# Altium schematic sheet InterlockControl.SchDoc
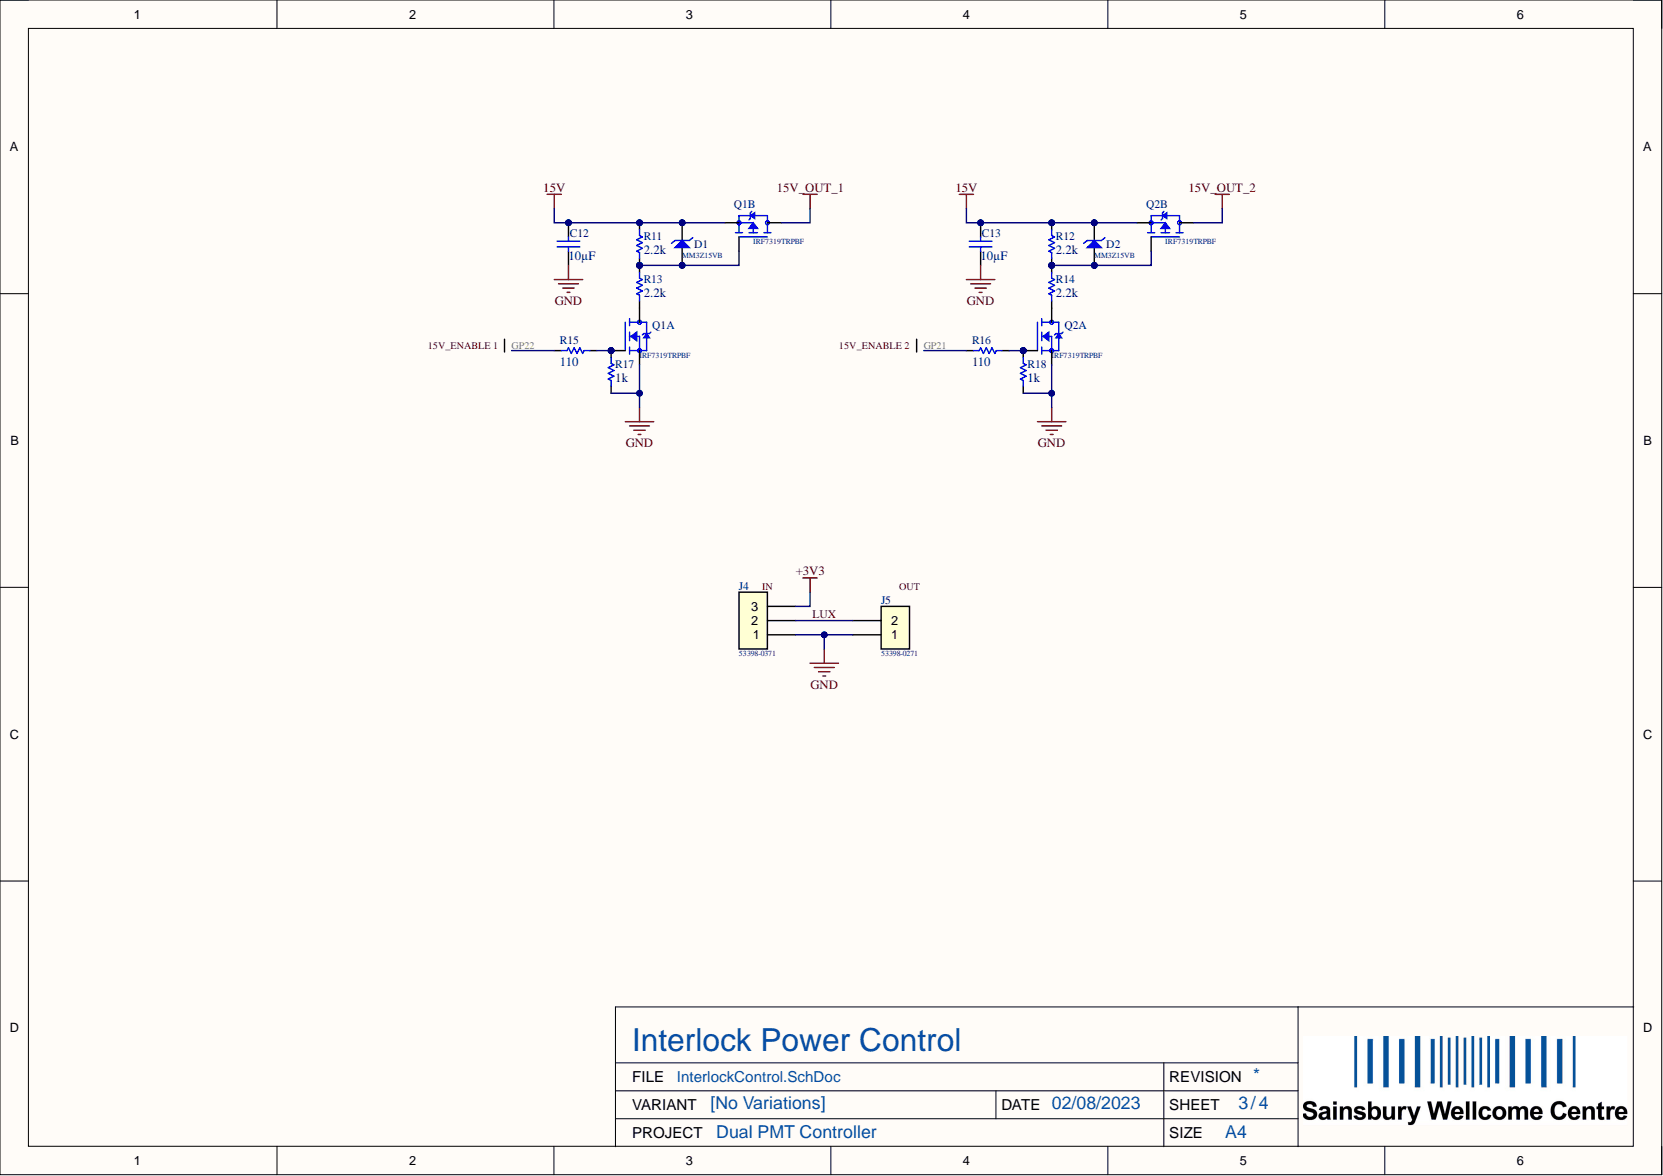
[diagram p0: InterlockControl.SchDoc]

## Parts
C12  value 10µF  [CAPC3225X279X50NL20T25]  (hidden comment: C1210-10uF-50V-10%-X7R)
C13  value 10µF  [CAPC3225X279X50NL20T25]  (hidden comment: C1210-10uF-50V-10%-X7R)
D1  MM3Z15VB  [SODFL250X100-2N]
D2  MM3Z15VB  [SODFL250X100-2N]
J4  53398-0371  [MOLX-53398-0371_V]
J5  53398-0271  [MOLX-53398-0271_V]
Q1  IRF7319TRPBF  [SOIC127P600X175-8N]  (parts A,B)
Q2  IRF7319TRPBF  [SOIC127P600X175-8N]  (parts A,B)
R11  value 2.2k  Resistance: 2.2k  [FP-CPF0603-IPC_B]  (hidden comment: R0603-2k2-1/16W-0.1%)
R12  value 2.2k  Resistance: 2.2k  [FP-CPF0603-IPC_B]  (hidden comment: R0603-2k2-1/16W-0.1%)
R13  value 2.2k  Resistance: 2.2k  [FP-CPF0603-IPC_B]  (hidden comment: R0603-2k2-1/16W-0.1%)
R14  value 2.2k  Resistance: 2.2k  [FP-CPF0603-IPC_B]  (hidden comment: R0603-2k2-1/16W-0.1%)
R15  value 110  [FP-CPF0603-IPC_B]  (hidden comment: R0603-110R-1/16W-0.1%)
R16  value 110  [FP-CPF0603-IPC_B]  (hidden comment: R0603-110R-1/16W-0.1%)
R17  value 1k  Resistance: 1k  [FP-CPF0603-IPC_B]  (hidden comment: R0603-1k-1/16W-0.1%)
R18  value 1k  Resistance: 1k  [FP-CPF0603-IPC_B]  (hidden comment: R0603-1k-1/16W-0.1%)

## Nets
15V: C12.2 C13.2 D1.2 D2.2 Q1.3 Q2.3 R11.2 R12.2
15V_OUT_1: Q1.5
15V_OUT_2: Q2.5
+3V3: J4.3
GND: C12.1 C13.1 J4.1 J5.1 Q1.1 Q2.1 R17.1 R18.1
GP21: R16.1
GP22: R15.1
LUX: J4.2 J5.2
NetD1_1: D1.1 Q1.4 R11.1 R13.2
NetD2_1: D2.1 Q2.4 R12.1 R14.2
NetQ1_2: Q1.2 R15.2 R17.2
NetQ1_7: Q1.7 R13.1
NetQ2_2: Q2.2 R16.2 R18.2
NetQ2_7: Q2.7 R14.1

## Library data of the parts
C12 C13 = CMP-611-0024-5: 10uF ±10% 50V Ceramic Capacitor X7R 1210 (3225 Metric); Pick&PlaceFootprint: C1210; Capacitance: 10uF; Capacitor Type: Ceramic; Case/Package: 1210; Component Kind: Standard; Dielectric: X7R; Mount: Surface Mount; RoHS: Yes; Tolerance: 10%; Voltage Rating: 50V; Library Name: Sainsbury Wellcome Centre
D1 D2 = CMP-822-0003-4: Zener Diode, 15V V(Z), 2%, 0.2W, Silicon, Unidirectional; PartNumber: MM3Z5V1B; Pick&PlaceFootprint: SOD323; Component Kind: Standard; Mount: Surface Mount; Library Name: Sainsbury Wellcome Centre
J4 = CMP-370-0033-4: Board Connector, 3 Contact(s), 1 Row(s), Male, Straight, 0.049 inch Pitch, Surface Mount Terminal, Locking, Natural Insulator, Receptacle; Board Mounting Option: SOLDER HOLDING POSTS; Body Breadth: 0.146inch; Body Depth: 0.185inch; Body Length: 0.335inch; Contact Pattern: RECTANGULAR; Contact Resistance: 20mR; Contact Style: Blade Pin; Dielectric Withstanding Voltage (AC): 250V; DIN Conformance: No; Durability: 30Cycles; Insulation Resistance: 100MR; Mating Information: MULTIPLE MATING PARTS AVAILABLE; Number Of Connectors: 1; Number of Contacts: 3; Option: General Purpose; Plating Thickness: 39uin; Polarization Key: POLARIZED HOUSING; Rated Current (Signal): 1A; Reference Standard: UL; Reliability: COMMERCIAL; Body/Shell Style: RECEPTACLE; Component Kind: Standard; Connector Type: BOARD CONNECTOR; Contact Finish - Mating: TIN (39) OVER NICKEL; Contact Finish - Termination: Tin (Sn); Contact Gender: Male; Contact Material: Bronze; Filter Feature: No; Flammability Rating: UL94 V-0; IEC Conformance: No; Insulation Color: Natural; Insulation Material: Nylon; JESD-609 Code: e3; Mating Contact Pitch: 0.049inch; Max Operating Temperature: 85°C; MIL Conformance: No; Min Operating Temperature: -40°C; Mixed Contacts: No; Mount: Board; Mounting Option 1: LOCKING; Mounting Style: STRAIGHT; Number Of PCB Rows: 1; Number of Rows Loaded: 1; PartNumber: 53398-0371; PCB Contact Pattern: RECTANGULAR; REACH SVHC: Yes; RoHS Compliant: Yes; Terminal Pitch: 1.25mm; Termination: SMD/SMT; Library Name: Sainsbury Wellcome Centre
J5 = CMP-370-0034-4: Board Connector, 2 Contact(s), 1 Row(s), Male, Straight, 0.049 inch Pitch, Surface Mount Terminal, Locking, Natural Insulator, Receptacle; Board Mounting Option: FITTING NAIL; Body Breadth: 0.165inch; Body Depth: 0.185inch; Body Length: 0.285inch; Contact Pattern: RECTANGULAR; Contact Resistance: 20mR; Contact Style: Blade Pin; Dielectric Withstanding Voltage (AC): 250V; DIN Conformance: No; Durability: 30Cycles; Insulation Resistance: 100MR; Mating Information: MULTIPLE MATING PARTS AVAILABLE; Number Of Connectors: 1; Number of Contacts: 2; Option: General Purpose; Plating Thickness: 39uin; Polarization Key: POLARIZED HOUSING; Rated Current (Signal): 1A; Reference Standard: UL; Reliability: COMMERCIAL; Body/Shell Style: RECEPTACLE; Component Kind: Standard; Connector Type: BOARD CONNECTOR; Contact Finish - Mating: MATTE TIN (39) OVER NICKEL; Contact Finish - Termination: Tin (Sn); Contact Gender: Male; Contact Material: Bronze; Filter Feature: No; Flammability Rating: UL94 V-0; IEC Conformance: No; Insulation Color: Natural; Insulation Material: Polyamide; JESD-609 Code: e3; Mating Contact Pitch: 0.049inch; Max Operating Temperature: 85°C; MIL Conformance: No; Min Operating Temperature: -40°C; Mixed Contacts: No; Mount: Board; Mounting Option 1: LOCKING; Mounting Style: STRAIGHT; Number Of PCB Rows: 1; Number of Rows Loaded: 1; PartNumber: 53398-0271; PCB Contact Pattern: RECTANGULAR; REACH SVHC: Yes; RoHS Compliant: Yes; Terminal Pitch: 1.25mm; Termination: SMD/SMT; Library Name: Sainsbury Wellcome Centre
Q1 Q2 = CMP-830-0006-2: Power Field-Effect Transistor, 6.5A I(D), 30V, 0.029ohm, 2-Element, N-Channel and P-Channel, Silicon, Metal-oxide Semiconductor FET, MS-012AA; Pick&PlaceFootprint: SOIC-8; Component Kind: Standard; Mount: Surface Mount; PartNumber: IRF7319TRPBF; Library Name: Sainsbury Wellcome Centre
R11-R14 = CMP-633-0070-10: Surface Mount Resistor 2.2k Ohm 1/16 W 0.1% 0603 (1608 Metric); Max Power Dissipation: 62.5 mW; Number of Resistors: 1; Pick&PlaceFootprint: R0603; Power Rating: 62.5mW; Temperature Coefficient: 25ppm/°C; Voltage Rating: 50V; Case Code (Imperial): 0603; Case Code (Metric): 1608; Case/Package: 0603; Component Kind: Standard; Composition: Thin Film; ELV: Compliant; Height: 0.45mm; Length: 1.6mm; Max Operating Temperature: 155°C; Min Operating Temperature: -55°C; Mount: Surface Mount; Number of Pins: 2; Number of Terminations: 2; Packaging: Tape and Reel; REACH SVHC: No SVHC; Resistance Tolerance: 0.1%; Resistor Type: Thin Film; RoHS: Yes; RoHS Compliant: Yes; Series: CPF; Size Code: 0603; Technology: Thin Film; Termination: Solder; Tolerance: 0.1%; Voltage Rating (DC): 50V; Weight: 7.1E-05oz; Width: 0.8mm; Library Name: Sainsbury Wellcome Centre
R15 R16 = CMP-633-0080-2: Surface Mount Resistor 110 Ohm 1/16 W 0.1% 0603 (1608 Metric); Max Power Dissipation: 62.5 mW; Number of Resistors: 1; Pick&PlaceFootprint: R0603; Power Rating: 62.5mW; Temperature Coefficient: 25ppm/°C; Voltage Rating: 50V; Case Code (Imperial): 0603; Case Code (Metric): 1608; Case/Package: 0603; Component Kind: Standard; Composition: Thin Film; Construction: Chip; ELV: Compliant; Height: 0.45mm; Length: 1.6mm; Max Operating Temperature: 155°C; Min Operating Temperature: -55°C; Mount: Surface Mount; Number of Pins: 2; Number of Terminations: 2; Packaging: Tape and Reel; REACH SVHC: Yes; Resistance: 110; Resistance Tolerance: 0.1%; Resistor Type: FIXED RESISTOR; RoHS: Yes; RoHS Compliant: Yes; Series: CPF; Size Code: 0603; Technology: THIN FILM; Termination: Wraparound; Tolerance: 0.1%; Voltage Rating (DC): 50V; Weight: 7.1E-05oz; Width: 0.8mm; Library Name: Sainsbury Wellcome Centre
R17 R18 = CMP-633-0013-15: Surface Mount Resistor 1k Ohm 1/16 W 0.1% 0603 (1608 Metric); Max Power Dissipation: 62.5 mW; Number of Resistors: 1; Pick&PlaceFootprint: R0603; Power Rating: 62.5mW; Temperature Coefficient: 25ppm/°C; Voltage Rating: 50V; Case Code (Imperial): 0603; Case Code (Metric): 1608; Case/Package: 0603; Component Kind: Standard; Composition: Thin Film; ELV: Compliant; Height: 0.45mm; Length: 1.6mm; Max Operating Temperature: 155°C; Min Operating Temperature: -55°C; Mount: Surface Mount; Number of Pins: 2; Number of Terminations: 2; Packaging: Tape and Reel; REACH SVHC: No SVHC; Resistance Tolerance: 0.1%; Resistor Type: Thin Film; RoHS: Yes; RoHS Compliant: Yes; Series: CPF; Size Code: 0603; Technology: Thin Film; Termination: Solder; Tolerance: 0.1%; Voltage Rating (DC): 50V; Weight: 7.1E-05oz; Width: 0.8mm; Library Name: Sainsbury Wellcome Centre
